# Altium schematic sheet Sheet1.SchDoc
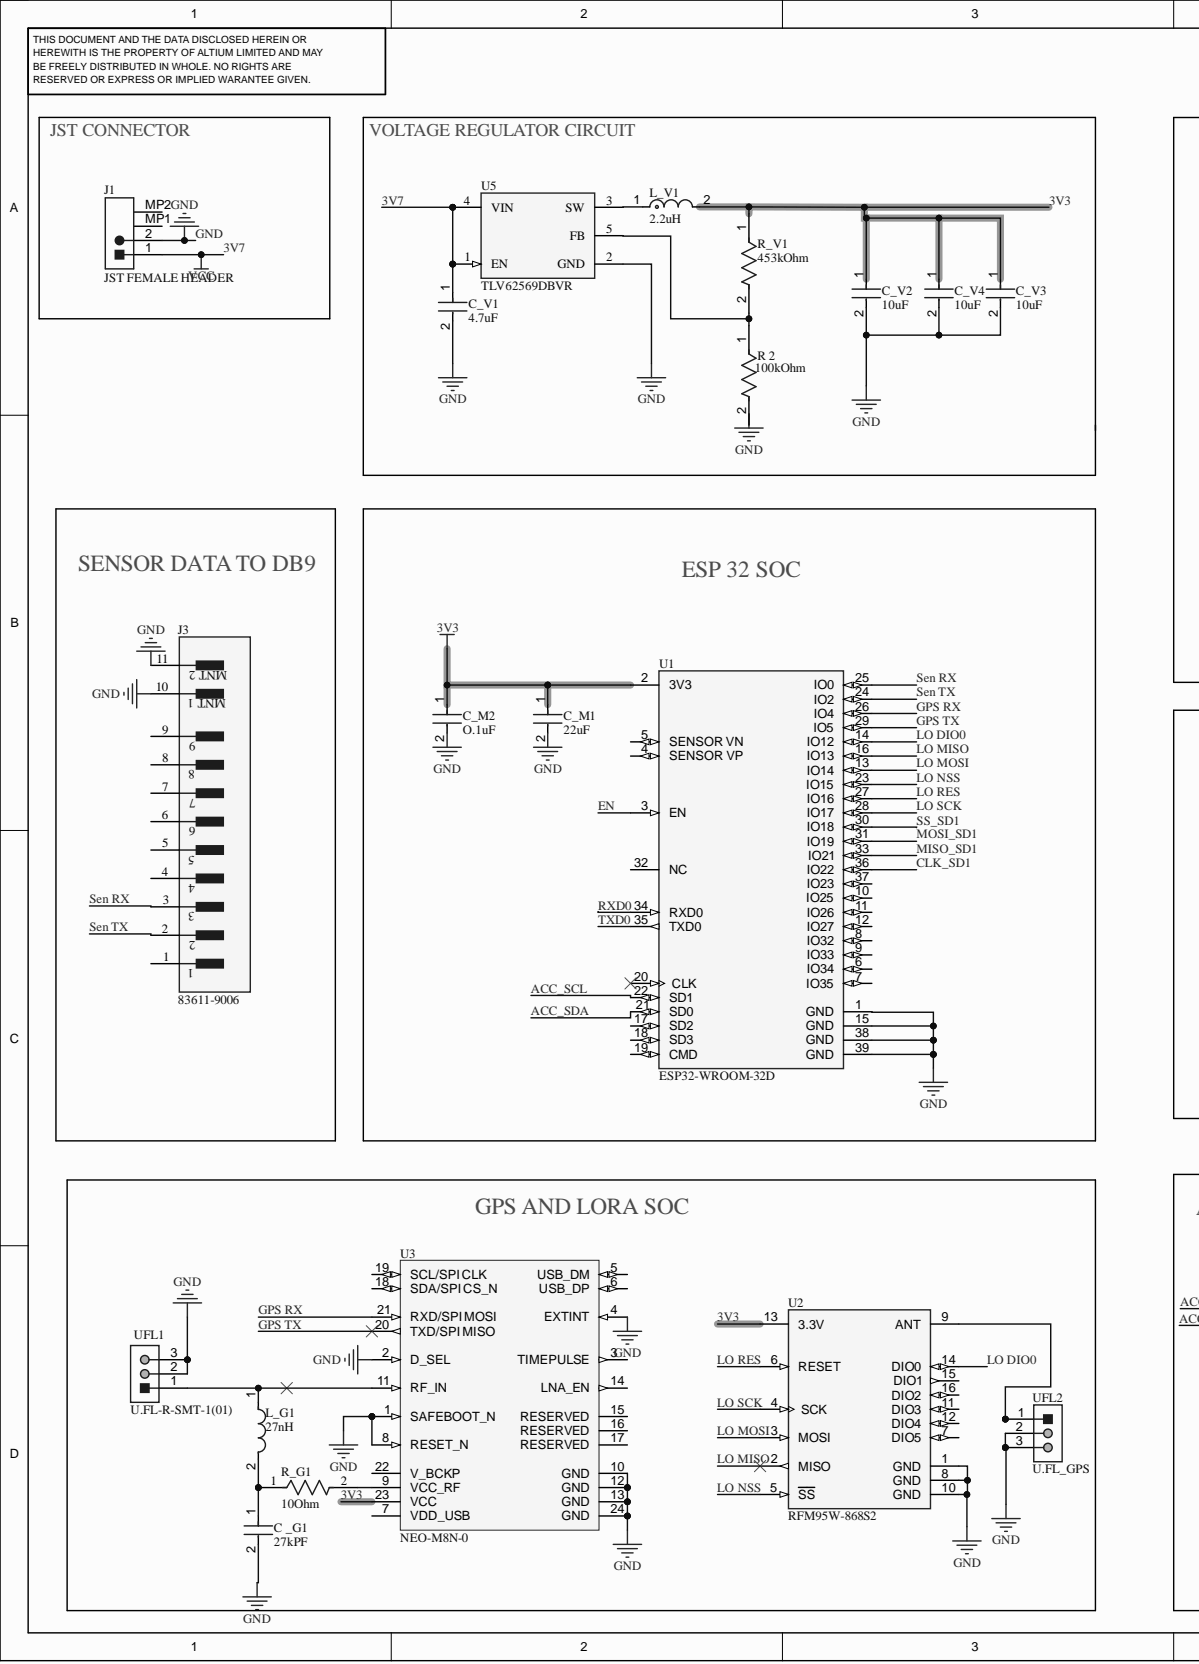
[diagram p0: Sheet1.SchDoc, left]
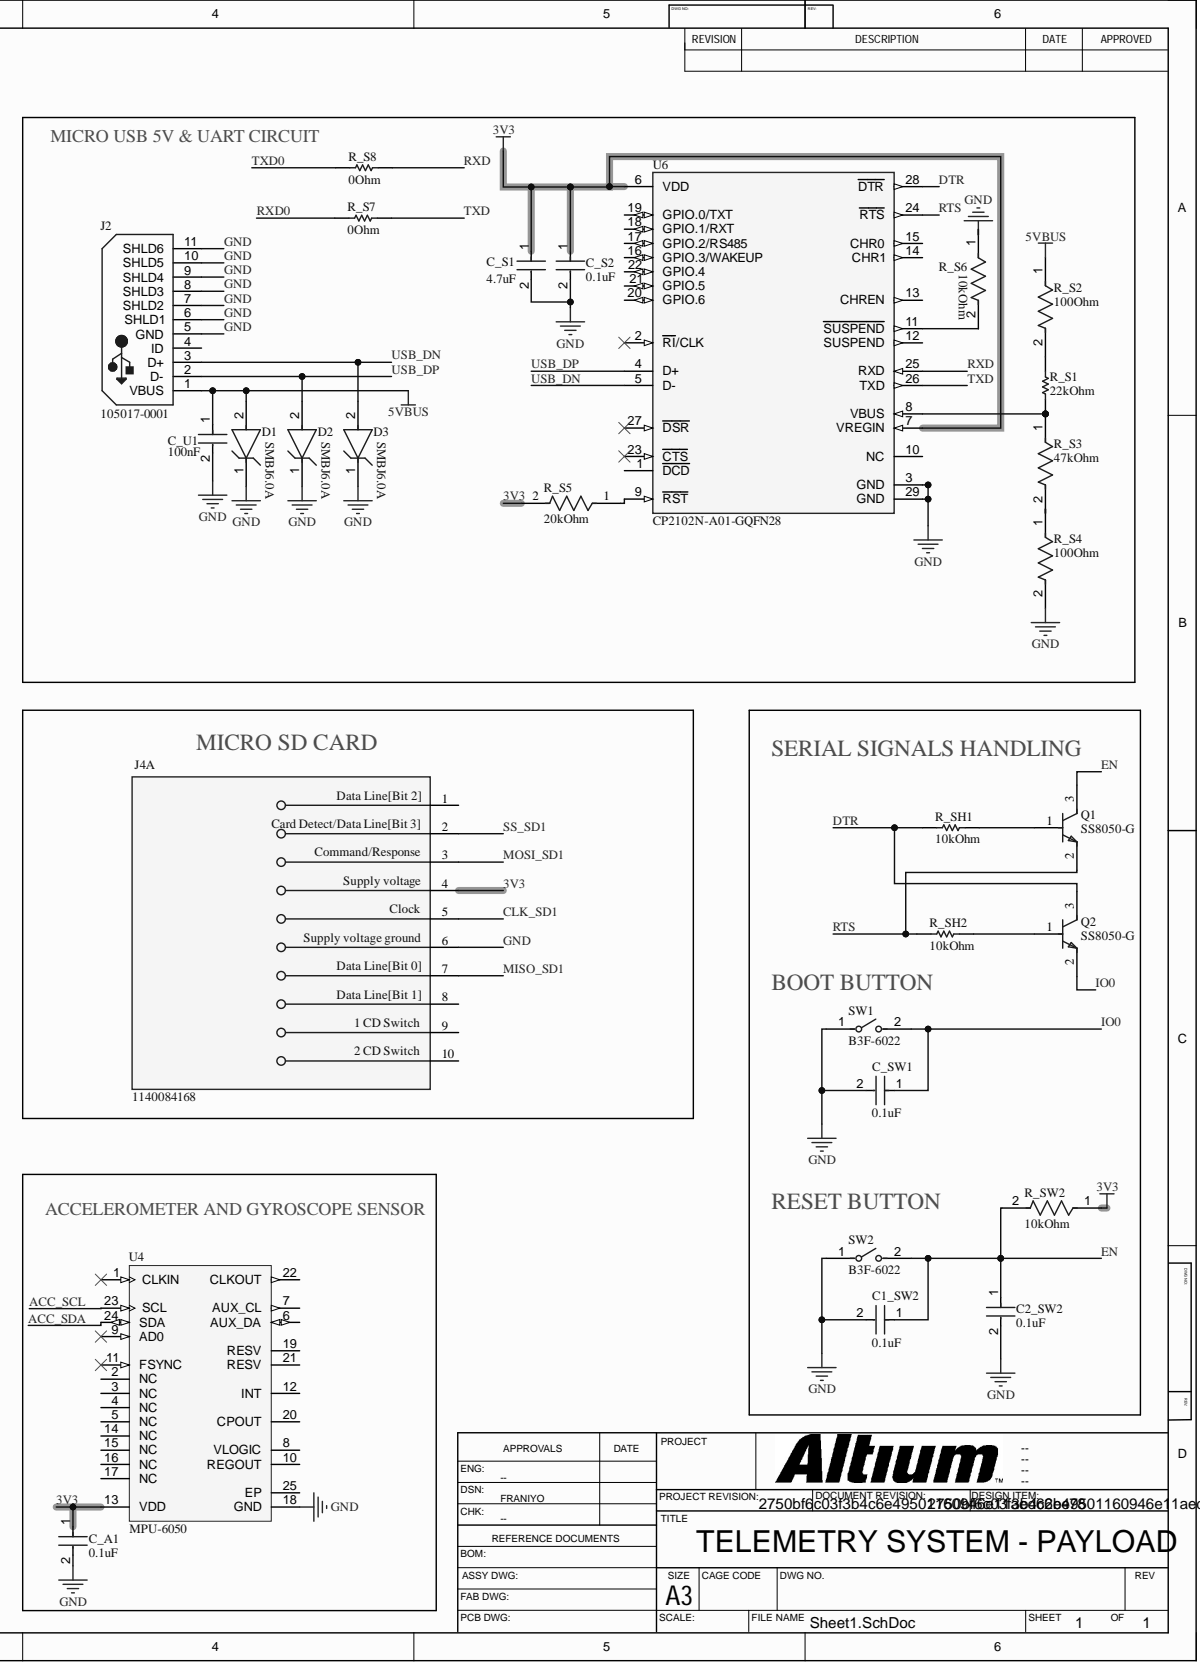
[diagram p1: Sheet1.SchDoc, right]

## Parts
C1_SW2  0.1uF  [FP-C0603C-CF-MFG]
C2_SW2  0.1uF  [FP-C0603C-CF-MFG]
C__G1  27kPF  [FP-C0805C-DN-MFG]
C_A1  0.1uF  [FP-C0402-BB-MFG]
C_M1  22uF  [FP-C2012-125-0_2-MFG]
C_M2  O.1uF  [FP-C0603C-CF-MFG]
C_S1  4.7uF  [FP-C0603-CG-MFG]
C_S2  0.1uF  [FP-C0603C-CF-MFG]
C_SW1  0.1uF  [FP-C0603C-CF-MFG]
C_U1  100nF  [FP-C1206C-EB-MFG]
C_V1  4.7uF  [FP-C1005-050-0_15-MFG]
C_V2  10uF  [FP-GRJ155-0_2-MFG]
C_V3  10uF  [FP-GRJ155-0_2-MFG]
C_V4  10uF  [FP-GRJ155-0_2-MFG]
D1  SMBJ6.0A  [FP-SMBJ-MFG]
D2  SMBJ6.0A  [FP-SMBJ-MFG]
D3  SMBJ6.0A  [FP-SMBJ-MFG]
J1  JST FEMALE HEADER  [JST- S2B-PH-SM4-TB(LF)(SN)-2_V]
J2  105017-0001  [USB-MICRO-B_V]
J3  83611-9006  [FP-83611-9006-MFG]
J4  1140084168  [FP-1140084168-0]  (part A)
L_G1  27nH  [FP-LQW18AN_00-MFG]
L_V1  2.2uH  [FP-XAL4020-MFG]
Q1  SS8050-G  [FP-SS8050-G-MFG]
Q2  SS8050-G  [FP-SS8050-G-MFG]
R_2  100kOhm  [FP-CRCW0402-C-e3-MFG]
R_G1  10Ohm  [FP-ERJ1GN-MFG]
R_S1  22kOhm  [RESC1608X55X30NL15T15]
R_S2  100Ohm  [FP-CRCW0603-e3-IPC_B]
R_S3  47kOhm  [FP-ERJ3G-IPC_B]
R_S4  100Ohm  [FP-CRCW0603-e3-IPC_B]
R_S5  20kOhm  [FP-ERJ3G-MFG]
R_S6  10kOhm  [FP-CRCW0805-e3-IPC_C]
R_S7  0Ohm  [RESC2013X60X35ML10T20]
R_S8  0Ohm  [RESC2013X60X35ML10T20]
R_SH1  10kOhm  [RESC2013X70X40LL20T20]
R_SH2  10kOhm  [RESC2013X70X40LL20T20]
R_SW2  10kOhm  [FP-CRCW0805-e3-IPC_C]
R_V1  453kOhm  [FP-CRCW0402-e3-MFG]
SW1  B3F-6022  [FP-B3F-6022-MFG]
SW2  B3F-6022  [FP-B3F-6022-MFG]
U1  ESP32-WROOM-32D  [FP-ESP32-WROOM-32D-MFG]
U2  RFM95W-868S2  [FP-RFM95W-868S2-MFG]
U3  NEO-M8N-0  [UBX-NEO-M8-24_V]
U4  MPU-6050  [IS-QFN-24_V]
U5  TLV62569DBVR  [FP-DBV0005A-MFG]
U6  CP2102N-A01-GQFN28  [FP-CP2102N-A01-GQFN28-MFG]
UFL1  U.FL-R-SMT-1(01)  [HRS-U.FL-R-SMT-3_V]
UFL2  U.FL_GPS  [HRS-U.FL-R-SMT-3_V]

## Nets
5VBUS: C_U1.1 D1.2 J2.1(VBUS) R_S2.1
3V3: C_A1.1 C_M1.1 C_M2.1 C_S1.1 C_S2.1 C_V2.1 C_V3.1 C_V4.1 J4.4 L_V1.2 R_S5.2 R_SW2.1 R_V1.1 U1.2(3V3) U2.13(3.3V) U3.23(VCC) U4.13(VDD) U6.6(VDD) U6.7(VREGIN)
3V7: C_V1.1 J1.1 U5.1(EN) U5.4(VIN)
ACC_SCL: U1.22(SD1) U4.23(SCL)
ACC_SDA: U1.21(SD0) U4.24(SDA)
CLK_SD1: J4.5 U1.36(IO22)
DTR: Q2.3 R_SH1.1 U6.28(DTR)
EN: C1_SW2.1 C2_SW2.1 Q1.3 R_SW2.2 SW2.2 U1.3(EN)
GND: C1_SW2.2 C2_SW2.2 C__G1.2 C_A1.2 C_M1.2 C_M2.2 C_S1.2 C_S2.2 C_SW1.2 C_U1.2 C_V1.2 C_V2.2 C_V3.2 C_V4.2 D1.1 D2.1 D3.1 J1.2 J2.5(GND) J2.6(SHLD1) J2.7(SHLD2) J2.8(SHLD3) J2.9(SHLD4) J2.10(SHLD5) J2.11(SHLD6) J3.10 J3.11 J4.6 R_2.2 R_S4.2 R_S6.1 SW1.1 SW2.1 U1.1(GND) U1.15(GND) U1.38(GND) U1.39(GND) U2.1(GND) U2.8(GND) U2.10(GND) U3.1(SAFEBOOT_N) U3.2(D_SEL) U3.4(EXTINT) U3.8(RESET_N) U3.10(GND) U3.12(GND) U3.13(GND) U3.24(GND) U4.18(GND) U5.2(GND) U6.3(GND) U6.29(GND) UFL1.2 UFL1.3 UFL2.2 UFL2.3
GPS RX: U1.26(IO4) U3.21(RXD/SPI_MOSI)
GPS TX: U1.29(IO5) U3.20(TXD/SPI_MISO)
IO0: C_SW1.1 Q2.2 SW1.2
LO DIO0: U1.14(IO12) U2.14(DIO0)
LO MISO: U1.16(IO13) U2.2(MISO)
LO MOSI: U1.13(IO14) U2.3(MOSI)
LO NSS: U1.23(IO15) U2.5(SS)
LO RES: U1.27(IO16) U2.6(RESET)
LO SCK: U1.28(IO17) U2.4(SCK)
MISO_SD1: J4.7 U1.33(IO21)
MOSI_SD1: J4.3 U1.31(IO19)
RTS: Q1.2 R_SH2.1 U6.24(RTS)
RXD: R_S8.2 U6.25(RXD)
RXD0: R_S7.1 U1.34(RXD0)
Sen RX: J3.3 U1.25(IO0)
Sen TX: J3.2 U1.24(IO2)
SS_SD1: J4.2 U1.30(IO18)
TXD: R_S7.2 U6.26(TXD)
TXD0: R_S8.1 U1.35(TXD0)
USB_DN: D3.2 J2.3(D+) U6.5(D-)
USB_DP: D2.2 J2.2(D-) U6.4(D+)
NetC _G1_1: C__G1.1 L_G1.2 R_G1.1
NetL_G1_1: L_G1.1 U3.11(RF_IN) UFL1.1
NetL_V1_1: L_V1.1 U5.3(SW)
NetQ1_1: Q1.1 R_SH1.2
NetQ2_1: Q2.1 R_SH2.2
NetR 2_1: R_2.1 R_V1.2 U5.5(FB)
NetR_G1_2: R_G1.2 U3.9(VCC_RF)
NetR_S1_1: R_S1.1 R_S2.2
NetR_S1_2: R_S1.2 R_S3.1 U6.8(VBUS)
NetR_S3_2: R_S3.2 R_S4.1
NetR_S5_1: R_S5.1 U6.9(RST)
NetR_S6_2: R_S6.2 U6.11(SUSPEND)
NetU2_9: U2.9(ANT) UFL2.1

Not connected: J1 MP1 MP2; J2 4(ID); J3 1 4 5 6 7 8 9; J4 1 8 9 10; U1 4(SENSOR_VP) 5(SENSOR_VN) 6(IO34) 7(IO35) 8(IO32) 9(IO33) 10(IO25) 11(IO26) 12(IO27) 17(SD2) 18(SD3) 19(CMD) 20(CLK) 32(NC) 37(IO23); U2 7(DIO5) 11(DIO3) 12(DIO4) 15(DIO1) 16(DIO2); U3 3(TIMEPULSE) 5(USB_DM) 6(USB_DP) 7(VDD_USB) 14(LNA_EN) 15(RESERVED) 16(RESERVED) 17(RESERVED) 18(SDA/SPI_CS_N) 19(SCL/SPI_CLK) 22(V_BCKP); U4 1(CLKIN) 2(NC) 3(NC) 4(NC) 5(NC) 6(AUX_DA) 7(AUX_CL) 8(VLOGIC) 9(AD0) 10(REGOUT) 11(FSYNC) 12(INT) 14(NC) 15(NC) 16(NC) 17(NC) 19(RESV) 20(CPOUT) 21(RESV) 22(CLKOUT) 25(EP); U6 1(DCD) 2(RI/CLK) 10(NC) 12(SUSPEND) 13(CHREN) 14(CHR1) 15(CHR0) 16(GPIO.3/WAKEUP) 17(GPIO.2/RS485) 18(GPIO.1/RXT) 19(GPIO.0/TXT) 20(GPIO.6) 21(GPIO.5) 22(GPIO.4) 23(CTS) 27(DSR)

## Library data of the parts
C1_SW2 C2_SW2 = CMP-2006-03061-2: CAP CER 0.1UF 50V X7R 0603; Manufacturer: KEMET; Manufacturer Part Number: C0603C104K5RACTU; Automotive Grade: Grade 1; Capacitance: 0.10 μF; Material: Ceramic; Temperature Coefficient: 30ppm/°C; Tolerance: 0.1; Value: 0.10 μF; Automotive: Yes; Category: Cap; Component Kind: Standard; ComponentLink2Description: Datasheet; ComponentLink2URL: https://api.kemet.com/component-edge/download/datasheet/C0603C100J5GACAUTO.pdf; Device Class L1: Passive Components; Device Class L2: Capacitors; Device Class L3: Ceramic Capacitors; Digikey Description: CAP CER 0.1UF 50V X7R 0603; Height: 0.87mm; Lead Free: Yes; Library Name: Altium Content Vault; Octopart: https://octopart.com/c0603c104k5ractu-kemet-139244; Package: C0603C-CF; RoHS: TRUE; Temperature: -55°C to +125°C; Temperature Characteristic: X7R; Temperature Range High: +125°C; Temperature Range Low: -55°C; Voltage Rating: 50 V
C__G1 = CMP-1036-04122-3: CAP CER 0.027UF 50V X7R 0805; Manufacturer: KEMET; Manufacturer Part Number: C0805C273K5RACTU; Capacitance: 27,000 pF; Temperature Coefficient: 30ppm/°C; Tolerance: 0.1; Value: 27,000 pF; Automotive: No; Category: Cap; Component Kind: Standard; ComponentLink2Description: Datasheet; ComponentLink2URL: https://content.kemet.com/datasheets/KEM_C1003_C0G_SMD.pdf; Device Class L1: Passive Components; Device Class L2: Capacitors; Device Class L3: Ceramic Capacitors; Digikey Description: CAP CER 0.027UF 50V X7R 0805; Height: 0.88mm; Ipc Land Pattern Name: CAPC200125X78; Lead Free: Yes; Library Name: Altium Content Vault; Material: Ceramic; Mouser Description: Multilayer Ceramic Capacitors MLCC - SMD/SMT 50volts 27000pF X7R 10%; Octopart: https://octopart.com/c0805c273k5ractu-kemet-131415; Package: C0805C-DN; RoHS: TRUE; Temperature: -55°C to +125°C; Temperature Characteristic: X7R; Temperature Range High: +125°C; Temperature Range Low: -55°C; Voltage Rating: 50 V
C_A1 = CMP-2008-02108-2: CAP CER 0.1UF 16V X7R 0402; Manufacturer: Kemet; Manufacturer Part Number: C0402C104K4RACTU; Capacitance: 0.10 μF; Temperature Coefficient: 15%; Tolerance: 0.1; Value: 0.10 μF; Automotive: No; Category: Cap; Component Kind: Standard; ComponentLink2Description: Datasheet; ComponentLink2URL: https://content.kemet.com/datasheets/KEM_C1002_X7R_SMD.pdf; Device Class L1: Passive Components; Device Class L2: Capacitors; Device Class L3: Ceramic Capacitors; Digikey Description: CAP CER 0.1UF 16V X7R 0402; Height: 0.55mm; Ipc Land Pattern Name: CAPC10050X50; Lead Free: Yes; Library Name: Altium Content Vault; Material: Ceramic; Mouser Description: Cap Ceramic 0.1uF 16V X7R 10% SMD 0402 125?C Paper T/R - Tape and Reel (Alt: C0402C104K4RAC TU); Octopart: https://octopart.com/c0402c104k4ractu-kemet-94158; Package: C0402-BB; RoHS: TRUE; Temperature: -55°C to +125°C; Temperature Characteristic: X7R; Temperature Range High: +125°C; Temperature Range Low: -55°C; Voltage Rating: 16 V
C_M1 = CMP-08246-005813-1: Multilayer Ceramic Capacitors 22μF ±20% 10V X5R SMD 0805; Capacitance: 22uF; Case Code (Imperial): 0805; Case Code (Metric): 2012; Case/Package: 0805; Component Kind: Standard; Construction: Flat; Depth: 1.25mm; Dielectric: X5R; Dielectric Material: Ceramic; Height: 1.25mm; Lead Free: Lead Free; Length: 2mm; Library Name: Altium Content Vault; Max Operating Temperature: 85°C; Min Operating Temperature: -55°C; Mount: Surface Mount; Number of Pins: 2; Package Quantity: 1; Packaging: Tape and Reel; REACH SVHC: No SVHC; Resistor Type: Ceramic; RoHS Compliant: Yes; Series: C; Termination: SMD/SMT; Thickness: 0.057inch; Tolerance: 20%; Voltage Rating: 10V; Voltage Rating (DC): 10V; Weight: 0.000194oz; Width: 0.049inch
C_M2 C_S2 C_SW1 = CMP-2006-03061-2: CAP CER 0.1UF 50V X7R 0603; Manufacturer: KEMET; Manufacturer Part Number: C0603C104K5RACTU; Automotive Grade: Grade 1; Capacitance: 0.10 μF; Temperature Coefficient: 30ppm/°C; Tolerance: 0.1; Value: 0.10 μF; Automotive: Yes; Category: Cap; Component Kind: Standard; ComponentLink2Description: Datasheet; ComponentLink2URL: https://api.kemet.com/component-edge/download/datasheet/C0603C100J5GACAUTO.pdf; Device Class L1: Passive Components; Device Class L2: Capacitors; Device Class L3: Ceramic Capacitors; Digikey Description: CAP CER 0.1UF 50V X7R 0603; Height: 0.87mm; Lead Free: Yes; Library Name: Altium Content Vault; Material: Ceramic; Octopart: https://octopart.com/c0603c104k5ractu-kemet-139244; Package: C0603C-CF; RoHS: TRUE; Temperature: -55°C to +125°C; Temperature Characteristic: X7R; Temperature Range High: +125°C; Temperature Range Low: -55°C; Voltage Rating: 50 V
C_S1 = CMP-2006-02256-2: CAP CER 4.7UF 6.3V X5R 0603; Manufacturer: Kemet; Manufacturer Part Number: C0603C475K9PACTU; Temperature Coefficient: 15%; Tolerance: 10%; Automotive: No; Capacitance: 4.7uF; Category: Cap; Component Kind: Standard; ComponentLink2Description: Datasheet; ComponentLink2URL: https://content.kemet.com/datasheets/KEM_C1006_X5R_SMD.pdf; Device Class L1: Passive Components; Device Class L2: Capacitors; Device Class L3: Ceramic Capacitors; Digikey Description: CAP CER 4.7UF 6.3V X5R 0603; Height: 0.9mm; Ipc Land Pattern Name: CAPC16080X80; Lead Free: Yes; Library Name: Altium Content Vault; Material: Ceramic; Mouser Description: Multilayer Ceramic Capacitors MLCC - SMD/SMT 6.3volts 4.7uF X5R 10%; Octopart: https://octopart.com/c0603c475k9pactu-kemet-104242; Package: C0603-CG; RoHS: TRUE; Temperature: -55°C to +85°C; Temperature Characteristic: X5R; Temperature Range High: +85°C; Temperature Range Low: -55°C; Value: 4.7uF; Voltage Rating: 6.3V
C_U1 = CMP-1037-04222-2: CAP CER 0.1UF 200V 10% X7R 1206; Manufacturer: KEMET; Manufacturer Part Number: C1206C104K2RACTU; Automotive Grade: Grade 0; Capacitance: 0.10 μF; Temperature Coefficient: 15%; Tolerance: 0.1; Value: 0.10 μF; Automotive: Yes; Category: Cap; Component Kind: Standard; ComponentLink2Description: Datasheet; ComponentLink2URL: https://content.kemet.com/datasheets/KEM_C1007_X8R_ULTRA_150C_SMD.pdf; Device Class L1: Passive Components; Device Class L2: Capacitors; Device Class L3: Ceramic Capacitors; Digikey Description: CAP CER 0.1UF 200V 10% X7R 1206; Height: 0.88mm; Ipc Land Pattern Name: CAPC320160X78; Lead Free: Yes; Library Name: Altium Content Vault; Material: Ceramic; Mouser Description: Multilayer Ceramic Capacitors MLCC - SMD/SMT 200volts 0.1uF X7R 10%; Octopart: https://octopart.com/c1206c104k2ractu-kemet-9138344; Package: C1206C-EB; RoHS: TRUE; Temperature: -55°C to +150°C; Temperature Characteristic: X7R; Temperature Range High: +150°C; Temperature Range Low: -55°C; Voltage Rating: 200 V
C_V1 = CMP-2000-05997-2: CAP CER 4.7UF 10V X5R 0402; Dielectric Material: Ceramic; Tolerance: 10%; Capacitance: 4.7uF; Case Code (Imperial): 0402; Case Code (Metric): 1005; Case/Package: 0402; Component Kind: Standard; Dielectric: X5R; Lead Free: Lead Free; Length: 0.039inch; Library Name: Altium Content Vault; Max Operating Temperature: 85°C; Min Operating Temperature: -55°C; Mount: Surface Mount; Package Quantity: 10000; Packaging: Cut Tape; REACH SVHC: No SVHC; RoHS Compliant: Yes; Series: C; Thickness: 0.022inch; Voltage Rating: 10V; Voltage Rating (DC): 10V; Width: 0.02inch
C_V2-C_V4 = CMP-06035-062410-1: CAP CER 10UF 6.3V X5R 0402; Tolerance: 20%; Capacitance: 10uF; Case Code (Imperial): 0402; Case Code (Metric): 1005; Case/Package: 0402; Component Kind: Standard; Features: Soft Termination; Length: 0.039inch; Library Name: Altium Content Vault; Max Operating Temperature: 85°C; Min Operating Temperature: -55°C; Mount: Surface Mount; Packaging: Cut Tape; RoHS Compliant: Yes; Thickness: 0.028inch; Voltage Rating: 6.3V; Width: 0.02inch
D1-D3 = CMP-08607-000013-2: TVS DIODE 6V 10.3V DO214AA; Manufacturer: Littelfuse Inc; Breakdown Voltage: 6.67V; Direction: Unidirectional; Max Junction Temp: +150°C; Number Of Channels: 1; Peak Pulse Current: 58.3A; Peak Pulse Power: 600W; Automotive: No; Category: Diode; Clamping Voltage: 10.3V; Component Kind: Standard; ComponentLink2Description: Datasheet; ComponentLink2URL: https://www.littelfuse.com/~/media/electronics/datasheets/tvs_diodes/littelfuse_tvs_diode_smbj_datasheet.pdf.pdf; Device Class L1: Circuit Protection; Device Class L2: TVS Diodes; Device Class L3: unset; Digikey Description: TVS DIODE 6V 10.3V DO214AA; Height: 2.61mm; Lead Free: Yes; Library Name: Altium Content Vault; Manufacturer Part Number: SMBJ6.0A; Mouser Description: In a Pack of 10, Littelfuse SMBJ6.0A, Uni-Directional TVS Diode, 600W, 2-Pin DO-214AA; Octopart: https://octopart.com/smbj6.0a-littelfuse-66100; Package: SMBJ; Reverse Standoff Voltage: 6V; RoHS: TRUE; Temperature: -65°C to +150°C; Temperature Range High: +150°C; Temperature Range Low: -65°C
J1 = CMP-1755-00001-1: Male Header, Pitch 2 mm, 1 x 2 Position, Height 5.5 mm, -25 to 85 degC, RoHS, Tape and Reel; Current Rating: 3 A; Colour: Black; Component Kind: Standard; ComponentLink1Description: Manufacturer URL; ComponentLink1URL: http://www.jst.com/home2.html; ComponentLink2Description: Datasheet; ComponentLink2URL: http://www.jst-mfg.com/product/pdf/eng/ePH.pdf; Contact Finish: Lead; Contact Material: Bronze; Contact Plating: Tin; DatasheetVersion: Dec-12; Height: 8.13 mm; Lead Pitch: 0.1 inch; Length: 101.19 mm; Library Name: Altium Content Vault; Max Operating Temperature: 105degC; Min Operating Temperature: -65degC; Mount: Through Hole; Package Quantity: 100; PackageDescription: Male Header, Pitch 2 mm, 1 x 2 Position, Height 5.5 mm; PackageReference: S2B-PH-SM4-TB(LF)(SN)-2; Packaging: Bulk; Pins: 40; Radiation Hardening: No; RoHSCompliant: True; Width: 2.34 mm
J2 = CMP-2000-05827-1: Micro-USB B Receptacle, Right Angle, Bottom Mount, Surface Mount, with Solder Tabs, -30 to 85 degC, 5-Pin USB, RoHS, Tape and Reel; Manufacturer: Molex; 3D Model: 105017-0001.stp; Catalog Drawings: 1050170001; Circuits: 5; Connector Type: USB-microB; Featured Product: Micro-USBConnectorsUSBConnectors; Mating Products: WM17145-ND-CABLEMICROUSBBTOSTDA1.0MWM17147-ND-CABLEMICROUSBBTOSTDA2.0MWM17146-ND-CABLEMICROUSBBTOSTDA1.5M; Online Catalog: Molex-MicroUSB; Other Names: WM1399DKR; Package Version: Rev. F, 12/2012; PCN Assembly/Origin: ResinSupplier21/Oct/2015; Voltage - Max: 30V; Category: Connectors,Interconnects; Component Kind: Standard; Datasheet URL: http://www.molex.com/elqNow/elqRedir.htm?ref=http://www.molex.com/webdocs/datasheets/pdf/en-us/1050170001_IO_CONNECTORS.pdf; Datasheet Version: 03/2016; Family: USB,DVI,HDMIConnectors; Features: Shielded,SolderRetention; Gender: Receptacle; Ingress Protection: -; Library Name: Altium Content Vault; Manufacturer URL: http://www.molex.com/; Mounting Technology: SurfaceMount; Mounting Type: BoardCutout,SurfaceMount,RightAngle; Number of Contacts: 5; Package Description: 5-Leads USB, Micro B, Body 7.5 x 5 mm, Pitch 0.65 mm; Package Reference: MICRO-B; Package URL: http://www.molex.com/pdm_docs/sd/1050170001_sd.pdf; Packaging: Digi-Reel®; RoHS Information: 1050170001CertofCompliance; Series: -; Specifications: -; Standard Package: 1
J3 = CMP-04774-000002-1: CONN MICRO-D PLUG 9POS R/A SLDR; Current Rating: 1A; Gender: Male; Insulation Resistance: 5GR; Max Supply Voltage: 350V; Number of Circuits: 9; Number of Ports: 1; Pitch: 1.27mm; Plating: Steel; Shielding: Shielded; Color: Black; Component Kind: Standard; Contact Material: Copper; Contact Plating: Gold; Depth: 7.82mm; ELV: Compliant; Flammability Rating: UL94 V-0; Halogen Free: Low Halogen; Housing Color: Black; Housing Material: Steel; Lead Free: Lead Free; Length: 19.94mm; Library Name: Altium Content Vault; Max Operating Temperature: 125°C; Min Operating Temperature: -55°C; Mount: Through Hole; Number of Contacts: 9; Number of Positions: 9; Number of Rows: 2; Number of Terminals/Pins: 9; Orientation: Right Angle; Package Quantity: 156; Packaging: Rail/Tube; Radiation Hardening: No; REACH SVHC: No SVHC; Series: Micro D; Shell Material: Steel; Shell Size: E; Termination: Solder; Voltage Rating: 350V; Voltage Rating (AC): 350V; Width: 16.89mm
J4 = CMP-02360-000003-1: CONN MICRO SD CARD PUSH-PULL R/A; Connector Type: Connector; Gender: Receptacle; Number of Contacts: 8; Pitch: 1.1mm; Component Kind: Standard; Contact Material: Copper Alloy; Contact Plating: Gold; Depth: 11.45mm; Flammability Rating: UL94 V-0; Height: 1.55mm; Housing Material: Polymer; Lead Free: Lead Free; Length: 15.6mm; Library Name: Altium Content Vault; Mount: Surface Mount; Number of Rows: 1; Orientation: Right Angle; Package Quantity: 7500; Packaging: Tape and Reel; Radiation Hardening: No; Termination: SMD/SMT
L_G1 = CMP-06042-006496-1: Wire Wound RF Inductor 27nH ±2% 440mA 0.21Ω 0603 (1608); Core Material: Non-Magnetic; Current: 850mA; DC Resistance (DCR): 210mR; Q Factor: 40; Self Resonant Frequency: 3.7GHz; Shielding: Unshielded; Test Frequency: 100MHz; Case Code (Imperial): 0603; Case Code (Metric): 1608; Case/Package: 0603; Component Kind: Standard; Current Rating: 440mA; DC Current: 440mA; Depth: 1mm; Height: 0.8mm; Height - Seated (Max): 0.039inch; Inductance: 27nH; Lead Free: Lead Free; Length: 1.6mm; Library Name: Altium Content Vault; Max DC Current: 440mA; Max Operating Temperature: 125°C; Military Standard: Not; Min Operating Temperature: -55°C; Mount: Surface Mount; Package Quantity: 4000; Packaging: Tape and Reel; Radiation Hardening: No; Resistor Type: Wirewound; Series Resistance: 210mR; Termination: SMD/SMT; Tolerance: 2%; Weight: 0.000106oz; Width: 0.031inch
L_V1 = CMP-11268-000134-1: Fixed Inductors 2.2uH Shld 20% 5.5A 38.7mOhms AECQ2; Core Material: Composite; DC Resistance (DCR): 38.7mR; Saturation Current: 5.6A; Self Resonant Frequency: 52MHz; Shielding: Shielded; Test Frequency: 100kHz; Case/Package: SMD/SMT; Component Kind: Standard; Height: 2.1mm; Inductance: 2.2uH; Inductance Tolerance: 20%; Lead Free: Contains Lead; Length: 4.3mm; Library Name: Altium Content Vault; Max DC Current: 5.5A; Max Operating Temperature: 125°C; Min Operating Temperature: -40°C; Mount: Surface Mount; Package Quantity: 1000; Packaging: Tape and Reel; RMS Current (Irms): 5.5A; RoHS Compliant: Yes; Series: XAL4020; Termination: SMD/SMT; Tolerance: 20%; Width: 4.3mm
Q1 Q2 = CMP-20235-000001-1: NPN TRANSISTOR 1.5A 40V SOT-23 R; Collector Emitter Breakdown Voltage: 25V; Collector Emitter Voltage (VCEO): 400mV; Max Power Dissipation: 300mW; Transition Frequency: 100MHz; Case/Package: TO-236-3; Component Kind: Standard; Library Name: Altium Content Vault; Max Breakdown Voltage: 25V; Max Collector Current: 1.5A; Mount: Surface Mount; Packaging: Tape and Reel; RoHS Compliant: Yes
R_2 = CMP-02407-010951-1: RES 100K OHM 1% 1/16W 0402; Power Rating: 62.5mW; Resistance: 100kR; Temperature Coefficient: 100ppm/°C; Case/Package: SMD/SMT; Component Kind: Standard; Contact Plating: Tin; Lead Free: Lead Free; Length: 1mm; Library Name: Altium Content Vault; Max Operating Temperature: 155°C; Min Operating Temperature: -55°C; Mount: Surface Mount; Resistor Type: Thick Film; RoHS Compliant: Yes; Termination: Wraparound; Tolerance: 1%
R_G1 = CMP-2004-03448-2: NexarPartId: 55419705; Max Power Dissipation: 50mW; Resistance: 10Ω; Temperature Coefficient: 200ppm/°C; Tolerance: 1%; Voltage Rating: 25V; Case Code (Imperial): 0201; Case Code (Metric): 0603; Case/Package: 0201; Component Kind: Standard; Composition: Thick Film; Contact Plating: Tin; Height: 254µm; Lead Free: Lead Free; Length: 600µm; Library Name: Altium Content Vault; Lifecycle Status: Production; Max Operating Temperature: 125°C; Min Operating Temperature: -55°C; Mount: Surface Mount; Number of Pins: 2; Number of Terminations: 2; Packaging: Cut Tape; Power Rating: 50mW; Radiation Hardening: No; REACH SVHC: No SVHC; RoHS: Compliant; Schedule B: 8533210045, 8533210045; Termination: SMD/SMT; Voltage Rating (DC): 25V; Weight: 170.097139µg; Width: 304.8µm; Working Voltage: 25V
R_S1 = CMP-1012-00106-2: Manufacturer: Panasonic; Part Number: ERJ-3GEYJ223V; Component Kind: Standard; Library Name: Altium Content Vault
R_S2 R_S4 = CMP-2000-03827-2: RES Thick Film, 100Ω, 5%, 0.1W, 200ppm/°C, 0603; Max Power Dissipation: 100mW; Resistance: 100R; Voltage Rating: 75V; Case Code (Imperial): 0603; Case Code (Metric): 1608; Case/Package: 0603; Component Kind: Standard; Composition: Thick Film; Contact Plating: Tin; Depth: 0.85mm; Features: Automotive AEC-Q200; Height: 0.02inch; Length: 1.55mm; Library Name: Altium Content Vault; Max Operating Temperature: 155°C; Military Standard: Not; Min Operating Temperature: -55°C; Number of Terminations: 2; Package Quantity: 1; Packaging: Tape and Reel; Power Rating: 100mW; Radiation Hardening: No; Temperature Coefficient: 200ppm/°C; Termination: SMD/SMT; Tolerance: 5%; Weight: 7.1E-05oz; Width: 0.85mm
R_S3 = CMP-1012-00114-3: RES SMD 47K OHM 5% 1/10W 0603; Max Power Dissipation: 100mW; Resistance: 47kR; Temperature Coefficient: 200ppm/°C; Voltage Rating: 75V; Voltage Rating (DC): 50V; Case Code (Imperial): 0603; Case Code (Metric): 1608; Case/Package: 0603; Component Kind: Standard; Construction: Rectangular; Contact Plating: Tin; Depth: 0.8mm; Features: Automotive AEC-Q200; Height: 0.45mm; Lead Free: Lead Free; Length: 1.6mm; Library Name: Altium Content Vault; Max Operating Temperature: 155°C; Military Standard: Not; Min Operating Temperature: -55°C; Mount: Surface Mount; Number of Terminations: 2; Package Quantity: 1; Packaging: Tape and Reel; Power Rating: 100mW; Radiation Hardening: No; REACH SVHC: No SVHC; Resistance Tolerance: 5%; Resistor Type: Thick Film; RoHS Compliant: Yes; Series: ERJ; Technology: THICK FILM; Termination: SMD/SMT; Tolerance: 5%; Width: 0.031inch; Working Voltage: 75V
R_S5 = CMP-2000-00741-2: NexarPartId: 55389241; Max Power Dissipation: 100mW; Resistance: 2kΩ; Temperature Coefficient: 200ppm/°C; Tolerance: 5%; Voltage Rating: 75V; Voltage Rating (DC): 50V; Case Code (Imperial): 0603; Case Code (Metric): 1608; Case/Package: 0603; Component Kind: Standard; Composition: Thick Film; Contact Plating: Tin; Depth: 800µm; Features: Automotive AEC-Q200; Height: 450µm; Lead Free: Lead Free; Length: 1.6mm; Library Name: Altium Content Vault; Lifecycle Status: Production; Max Operating Temperature: 155°C; Military Standard: Not; Min Operating Temperature: -55°C; Mount: Surface Mount; Number of Terminations: 2; Packaging: Cut Tape; Power Rating: 100mW; Radiation Hardening: No; REACH SVHC: No SVHC; RoHS: Compliant; Schedule B: 8533210045, 8533210045; Termination: SMD/SMT; Width: 787.4µm
R_S6 R_SW2 = CMP-2001-04910-2: RES Thick Film, 10kΩ, 5%, 0.125W, 200ppm/°C, 0805; Power Rating: 125mW; Resistance: 10kR; Voltage Rating: 150V; Case Code (Imperial): 0805; Case Code (Metric): 2012; Case/Package: 0805; Component Kind: Standard; Composition: Thick Film; Contact Plating: Tin; Depth: 1.25mm; Height: 0.45mm; Lead Free: Lead Free; Length: 2mm; Library Name: Altium Content Vault; Max Operating Temperature: 155°C; Military Standard: Not; Min Operating Temperature: -55°C; Mount: Surface Mount; Package Quantity: 5000; Packaging: Tape and Reel; Radiation Hardening: No; Temperature Coefficient: 200ppm/°C; Termination: SMD/SMT; Tolerance: 5%; Voltage Rating (DC): 150V; Weight: 0.000194oz; Width: 1.25mm
R_S7 R_S8 = CMP-2100-03673-1: Chip Resistor, 0 Ohm, Jumper, +/- 5%, 0.125 W, -55 to 155 degC, 0805 (2012 Metric), RoHS, Tape and Reel; Manufacturer: Yageo; Power Rating: 125mW; Resistance: 0mOhm; Temperature Coefficient: 200ppm/degreesC; Voltage Rating: 150V; Case Code (Imperial): 0805; Case Code (Metric): 2012; Case/Package: 0805; Component Kind: Standard; Composition: ThickFilm; Contact Plating: Tin; Datasheet URL: http://www.yageo.com/NewPortal/yageodocoutput?fileName=/pdf/R-Chip/PYu-RC_Group_51_RoHS_L_5.pdf; Datasheet Version: Rev. 5, 10/2016; Depth: 1.25mm; Height: 0.5mm; Lead Free: LeadFree; Length: 2mm; Library Name: Altium Content Vault; Manufacturer URL: http://www.yageo.com/; Max Operating Temperature: 155degC; Military Standard: Not; Min Operating Temperature: -55degC; Number of Pins: 2; Package Description: 2-Pin Surface Mounted Device, Body 2 x 1.25 mm; Package Reference: 0805; Packaging: TapeandReel; Radiation Hardening: No; REACH SVHC: Unknown; RoHSCompliant: true; Termination: SMD/SMT; Tolerance: 5%; Voltage Rating (DC): 150V; Width: 1.25mm
R_SH1 R_SH2 = CMP-2001-00337-1: Manufacturer: Panasonic; Part Number: ERJ-6GEYJ103V; Component Kind: Standard; Library Name: Altium Content Vault
R_V1 = CMP-2002-00012-2: RES Thick Film, 453kΩ, 1%, 0.063W, 100ppm/°C, 0402; Max Power Dissipation: 63mW; Resistance: 453kR; Voltage Rating: 50V; Case Code (Imperial): 0402; Case Code (Metric): 1005; Case/Package: 0402; Component Kind: Standard; Construction: Rectangular; Contact Plating: Tin; Depth: 0.5mm; Features: Automotive AEC-Q200; Height: 0.016inch; Lead Free: Lead Free; Length: 1mm; Library Name: Altium Content Vault; Max Operating Temperature: 155°C; Military Standard: Not; Min Operating Temperature: -55°C; Number of Pins: 2; Number of Terminations: 2; Package Quantity: 10; Packaging: Tape and Reel; Power Rating: 63mW; Radiation Hardening: No; Resistance Tolerance: 1%; Resistor Type: Thick Film; RoHS Compliant: Yes; Series: CRCW; Size Code: 0402; Temperature Coefficient: 100ppm/°C; Termination: SMD/SMT; Tolerance: 1%; Voltage Rating (DC): 50V; Weight: 2.3E-05oz; Width: 0.5mm
SW1 SW2 = CMP-08834-000012-1: SWITCH TACTILE SPST-NO 0.05A 24V; Actuator Type: Standard; Illumination Type: Non-Illuminated; Mechanical Life: 300000Cycles; Operating Force: 1.47N; Switch Function: Off-Mom; Switch Travel: 0.25mm; Throw Configuration: SPST; Case/Package: Radial; Component Kind: Standard; Contact Plating: Gold; Library Name: Altium Content Vault; Max Operating Temperature: 70°C; Max Voltage Rating (DC): 24V; Min Operating Temperature: -25°C; Mount: Through Hole; Package Quantity: 1000; Packaging: Ammo Pack; Termination: PC Pins
U1 = CMP-194065-000003-1: WIFI MODULE 32MBITS SPI FLASH; Manufacturer: Espressif Systems; Bandwidth: 72MHz; Data Rate: 150Mbits/s; Interface: I2C,SPI,UART,Ethernet,I2S,Other; Max Frequency: 2484MHz; Max Supply Voltage: 3.6V; Min Supply Voltage: 3V; Nominal Supply Current: 500mA; Number Of Adc Channels: 16; Number Of Gpio: 32; Sensitivity: -98dBm; Automotive: No; Category: UNK; Component Kind: Standard; ComponentLink2Description: Datasheet; ComponentLink2URL: https://www.espressif.com/sites/default/files/documentation/esp32-wroom-32d_esp32-wroom-32u_datasheet_en.pdf; Device Class L1: Integrated Circuits (ICs); Device Class L2: RF Semiconductors and Devices; Device Class L3: Transceivers; Digikey Description: WIFI MODULE 32MBITS SPI FLASH; Height: 3.2mm; Lead Free: Yes; Library Name: Altium Content Vault; Manufacturer Part Number: ESP32-WROOM-32D; Mouser Description: Wi-Fi/BT BLE Module ESP32-D0WD, SPI Flash, UART, P; Octopart: https://octopart.com/esp32-wroom-32d-espressif+systems-91040050; Package: ESP32-WROOM-32D; RoHS: TRUE; Temperature: -40°C to +85°C; Temperature Range High: +85°C; Temperature Range Low: -40°C
U2 = CMP-204316-000001-1: RX TXRX MODULE ISM < 1GHZ SMD; Current - Receiving: 12.1mA; Current - Transmitting: 120mA; Data Rate: 300Kbps; Frequency: 868MHz; Interface: SPI; Sensitivity: -123dBm; Case/Package: SMD/SMT; Component Kind: Standard; Library Name: Altium Content Vault; Max Operating Temperature: 70°C; Min Operating Temperature: -20°C; Mount: Surface Mount; Packaging: Bulk
U3 = CMP-2000-07559-1: U-Blox M8 Concurrent Gnss Module, 3.6 V, -40 to 85 degC, 24-Pin SMD, RoHS, Tape and Reel; Component Kind: Standard; Datasheet URL: https://www.u-blox.com/sites/default/files/NEO-M8-FW3_DataSheet_%28UBX-15031086%29.pdf; Datasheet Version: Rev. R03, 08/2016; Library Name: Altium Content Vault; Manufacturer: U-Blox; Manufacturer URL: https://www.u-blox.com/en; Mounting Technology: SurfaceMount; Package Description: 24-Pin Surface Mount Device, Body 16 x 12.2 mm, Pitch 1.1 mm; Package Reference: NEO-M8N-0; Package URL: https://www.u-blox.com/sites/default/files/NEO-8Q-NEO-M8-FW3_HardwareIntegrationManual_%28UBX-15029985%29.pdf; RoHSCompliant: False
U4 = CMP-1753-00002-2: 3-Axis Gyroscope, Accelerometer and a Digital Motion Processor(TM) (DMP), 2.375 to 3.46 V, -40 to 85 degC, 24-Pin QFN, RoHS, Tape and Reel; Manufacturer: InvenSense; Dynamic Catalog: MPU-6000™Series; Featured Product: MPU-60506-Axis,IntegratedI²CSolution; Other Names: 1428-1007-2  MPU6050; Sensor Type: MotionTracking; Category: Sensors,Transducers; Component Kind: Standard; Datasheet URL: http://datasheet.octopart.com/MPU-6050-InvenSense-datasheet-14421344.pdf; Datasheet Version: Rev. 3.3, 05/2012; Family: Multifunction; Library Name: Altium Content Vault; Manufacturer URL: http://www.invensense.com/; Online Catalog: MPU-6000™Series; Output Type: Digital; Standard Package: 5,000; Unit PriceUSD: Digital
U5 = CMP-04918-000387-1: IC REG BUCK ADJ 2A SOT23-5; Max Input Voltage: 5.5V; Min Input Voltage: 2.5V; Min Output Voltage: 600mV; Output Configuration: Positive; Output Type: Adjustable; Switching Frequency: 1.5MHz; Synchronous Rectifier: Yes; Case/Package: SOT-23-5; Component Kind: Standard; Library Name: Altium Content Vault; Max Operating Temperature: 125°C; Max Output Voltage: 5.5V; Min Operating Temperature: -40°C; Mount: Surface Mount; Output Current: 2A; Packaging: Cut Tape; RoHS Compliant: Yes
U6 = CMP-08093-000004-1: IC BRIDGE USB TO UART 28QFN; Manufacturer: Silicon Labs; Interface: UART,USB,RS-232,RS-485; Max Junction Temp: +105°C; Max Supply Voltage: 5.25V; Min Supply Voltage: 1.71V; Nominal Supply Current: 195-13700uA; Number Of Ports: 1; Standoff Height: 0mm; Usb Standard: USB 2.0; Ambient Temperature Range High: +85°C; Ambient Temperature Range Low: -40°C; Automotive: No; Category: IC; Component Kind: Standard; ComponentLink2Description: Datasheet; ComponentLink2URL: https://media.digikey.com/pdf/Data%20Sheets/Silicon%20Laboratories%20PDFs/CP2102N_Rev.1.3_DS.pdf; Device Class L1: Integrated Circuits (ICs); Device Class L2: Interface ICs; Device Class L3: USB Interface ICs; Digikey Description: IC BRIDGE USB TO UART 28QFN; Height: 0.8mm; Ipc Land Pattern Name: QFN50P500X500X75-28; Lead Free: Yes; Library Name: Altium Content Vault; Manufacturer Part Number: CP2102N-A01-GQFN28; Mouser Description: IC BRIDGE USB TO UART 28QFN; Octopart: https://octopart.com/cp2102n-a01-gqfn28-silicon+labs-74636024; Package: CP2102N-A01-GQFN28; RoHS: TRUE; Temperature: -40°C to +85°C; Temperature Range High: +85°C; Temperature Range Low: -40°C
UFL1 UFL2 = CMP-2000-05153-1: Ultra Small Coaxial Connector, 6 GHz, -40 to 90 degC, 3-Pin SMD, RoHS, Bulk; Manufacturer: Hirose Electric; Body Finish: Silver; Body Material: PhosphorBronze; Center Contact Plating: Gold; Connector Style: U.FL; Connector Type: Jack,MalePin; Contact Termination: Solder; Dielectric Material: LiquidCrystalPolymer(LCP); Dynamic Catalog: U.FLSeries; Featured Product: HiroseU.FLSeries; Frequency - Max: 6GHz; Impedance: 50Ohm; Minimum Quantity: UltraMiniatureCoaxial; Other Names: H122041  U.FL-R-SMT-1(01)-ND; Category: Connectors,Interconnects; Center Contact Material: PhosphorBronze; Component Kind: Standard; Datasheet URL: https://datasheet.ciiva.com/26945/getdatasheetpartid-475239-26945414.pdf; Datasheet Version: Feb-09; Family: CoaxialConnectors(RF); Fastening Type: Snap-On; Housing Color: Silver; Library Name: Altium Content Vault; Manufacturer URL: https://www.hirose.com; Mounting Type: SurfaceMount; Online Catalog: U.FLSeries; Operating Temperature: -40degC~90degC; Package Description: 3-Pin Surface Mount Device, Body 2.6 x 2.6 mm; Package Reference: U.FL-R-SMT; Packaging: Bulk; Quantity Available: Bulk; Series: U.FL; Shield Termination: Solder; Standard Package: 100; Unit PriceUSD: U.FL
